# Altium schematic sheet Task 1 Sch.SchDoc("Task 1 Sch")
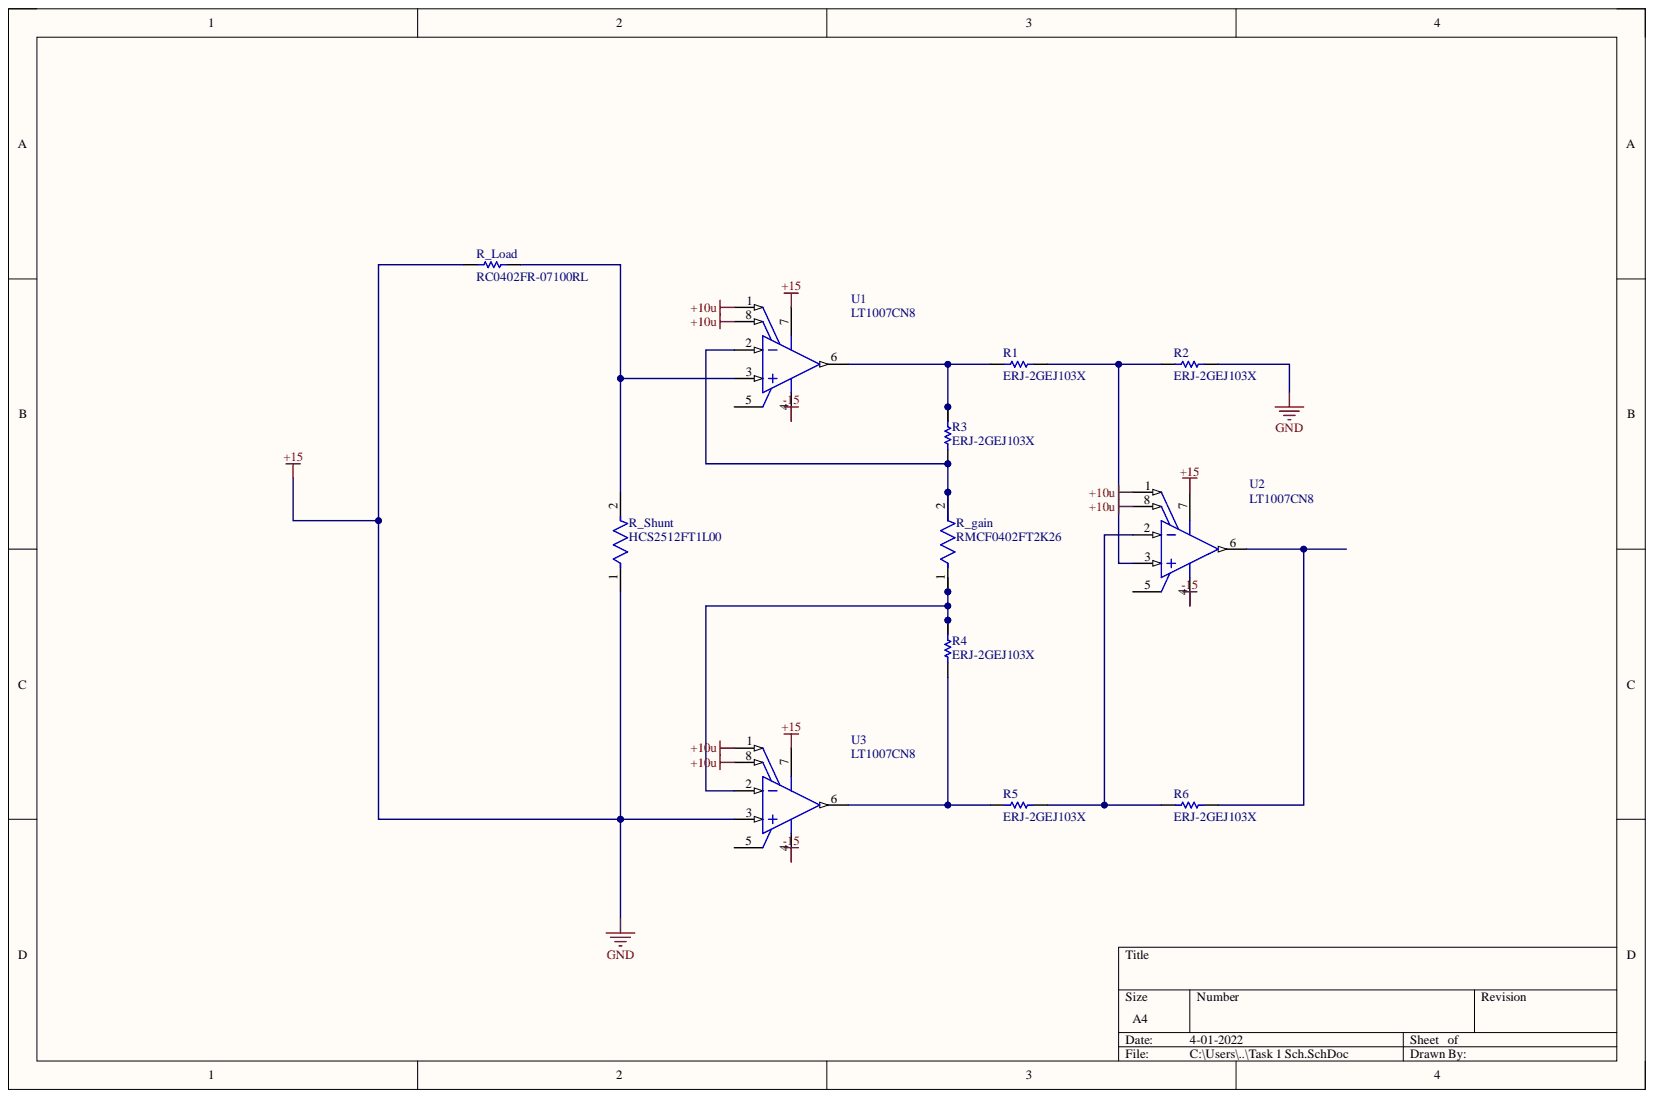
[diagram p0: Task 1 Sch.SchDoc]

## Parts
R1  ERJ-2GEJ103X  [RESC1005X40X25ML05T05]
R2  ERJ-2GEJ103X  [RESC1005X40X25ML05T05]
R3  ERJ-2GEJ103X  [RESC1005X40X25ML05T05]
R4  ERJ-2GEJ103X  [RESC1005X40X25ML05T05]
R5  ERJ-2GEJ103X  [RESC1005X40X25ML05T05]
R6  ERJ-2GEJ103X  [RESC1005X40X25ML05T05]
R_gain  RMCF0402FT2K26  [FP-RMCF0402-MFG]
R_Load  RC0402FR-07100RL  [RESC1005X40X25ML05T10]
R_Shunt  HCS2512FT1L00  [FP-HCS2512FT1L00-MFG]
U1  LT1007CN8  [LT-N8-8]
U2  LT1007CN8  [LT-N8-8]
U3  LT1007CN8  [LT-N8-8]

## Nets
+10u: U1.1 U1.8 U2.1 U2.8 U3.1 U3.8
+15: R2.2 R_Load.1 R_Shunt.1 U1.7 U2.7 U3.3 U3.7
-15: U1.4 U2.4 U3.4
NetR1_1: R1.1 R3.2 U1.6
NetR1_2: R1.2 R2.1 U2.3
NetR3_1: R3.1 R_gain.2 U1.2
NetR4_1: R4.1 R5.1 U3.6
NetR4_2: R4.2 R_gain.1 U3.2
NetR5_2: R5.2 R6.1 U2.2
NetR6_2: R6.2 U2.6
NetR_Load_2: R_Load.2 R_Shunt.2 U1.3

Not connected: U1 5; U2 5; U3 5

## Library data of the parts
R1-R6 = CMP-2002-00433-1: Manufacturer: Panasonic; Component Kind: Standard; Library Name: Altium Content Vault; Part Number: ERJ-2GEJ103X
R_gain = CMP-26527-002239-1: 2.26kΩ ±1% 0.063W 0402 Thick Film Chip Resistor AEC-Q200 compliant; Max Power Dissipation: 63mW; Resistance: 2.26kR; Temperature Coefficient: 100ppm/°C; Case Code (Imperial): 0402; Case Code (Metric): 1005; Case/Package: 0402; Component Kind: Standard; Contact Plating: Tin; Features: Automotive AEC-Q200; Height: 0.016inch; Lead Free: Lead Free; Length: 0.039inch; Library Name: Altium Content Vault; Max Operating Temperature: 155°C; Min Operating Temperature: -55°C; Mount: Surface Mount; Number of Terminations: 2; Packaging: Tape and Reel; Radiation Hardening: No; Resistor Type: Thick Film; Series: RMCF; Tolerance: 1%; Width: 0.02inch
R_Load = CMP-2002-07344-1: Manufacturer: Yageo / Phycomp; Component Kind: Standard; Library Name: Altium Content Vault; Part Number: RC0402FR-07100RL
R_Shunt = CMP-26527-002753-1: RES 0.001 OHM 1% 3W 2512; Resistance: 1mR; Temperature Coefficient: 100ppm/°C; Case Code (Imperial): 2512; Case Code (Metric): 6432; Case/Package: 2512; Component Kind: Standard; Features: Moisture Resistant; Height: 0.021inch; Length: 0.256inch; Library Name: Altium Content Vault; Max Operating Temperature: 170°C; Max Power Dissipation: 3W; Min Operating Temperature: -55°C; Number of Terminations: 2; Package Quantity: 4000; Packaging: Tape and Reel; Resistor Type: Metal Element; RoHS Compliant: Yes; Tolerance: 1%; Width: 0.128inch
U1-U3 = CMP-0359-01259-2: Single Channel, Low Noise, High Speed Precision Operational Amplifier, 8 MHz Typical GBW, 2.5 V/us Typical SR, 4 to 44 V, 8-Pin DIP (N8-8), Commerical; Linear Technology Part Number: LT1007; Manufacturer: Linear Technology; Channels (#): 1; GBW (MHz) Typical: 8; Is (mA) Typical: 2.6; SR (V/us) Typical: 2.5; Vos (mV) Typical: 0.01; Vs Max (V): 44; Vs Min (V): 4; Component Kind: Standard; ComponentLink1Description: Manufacturer URL; ComponentLink1URL: http://www.linear.com/; ComponentLink2Description: Datasheet; ComponentLink2URL: http://cds.linear.com/docs/en/datasheet/1007dicedwffa.pdf; ComponentLink3Description: Package Specification; ComponentLink3URL: http://cds.linear.com/docs/en/packaging/05081510_I_N8.pdf; DatasheetVersion: Rev. A; Library Name: Altium Content Vault; Mounting Technology: Surface Mount; PackageDescription: 8-Lead PDIP, Body 10.16 x 6.48 mm, Pitch 2.54 mm; PackageReference: LT-N8-8; PackageVersion: Rev I; PartNumber: LT1007CN8; RevisionNote: URLs updated; Type: VFB
